# Altium schematic sheet J1708 LIN H-Bridge CAN1 I2C Pots Rev 5.SchDoc("J1708 LIN H-Bridge CAN1 I2C Pots Rev 5")
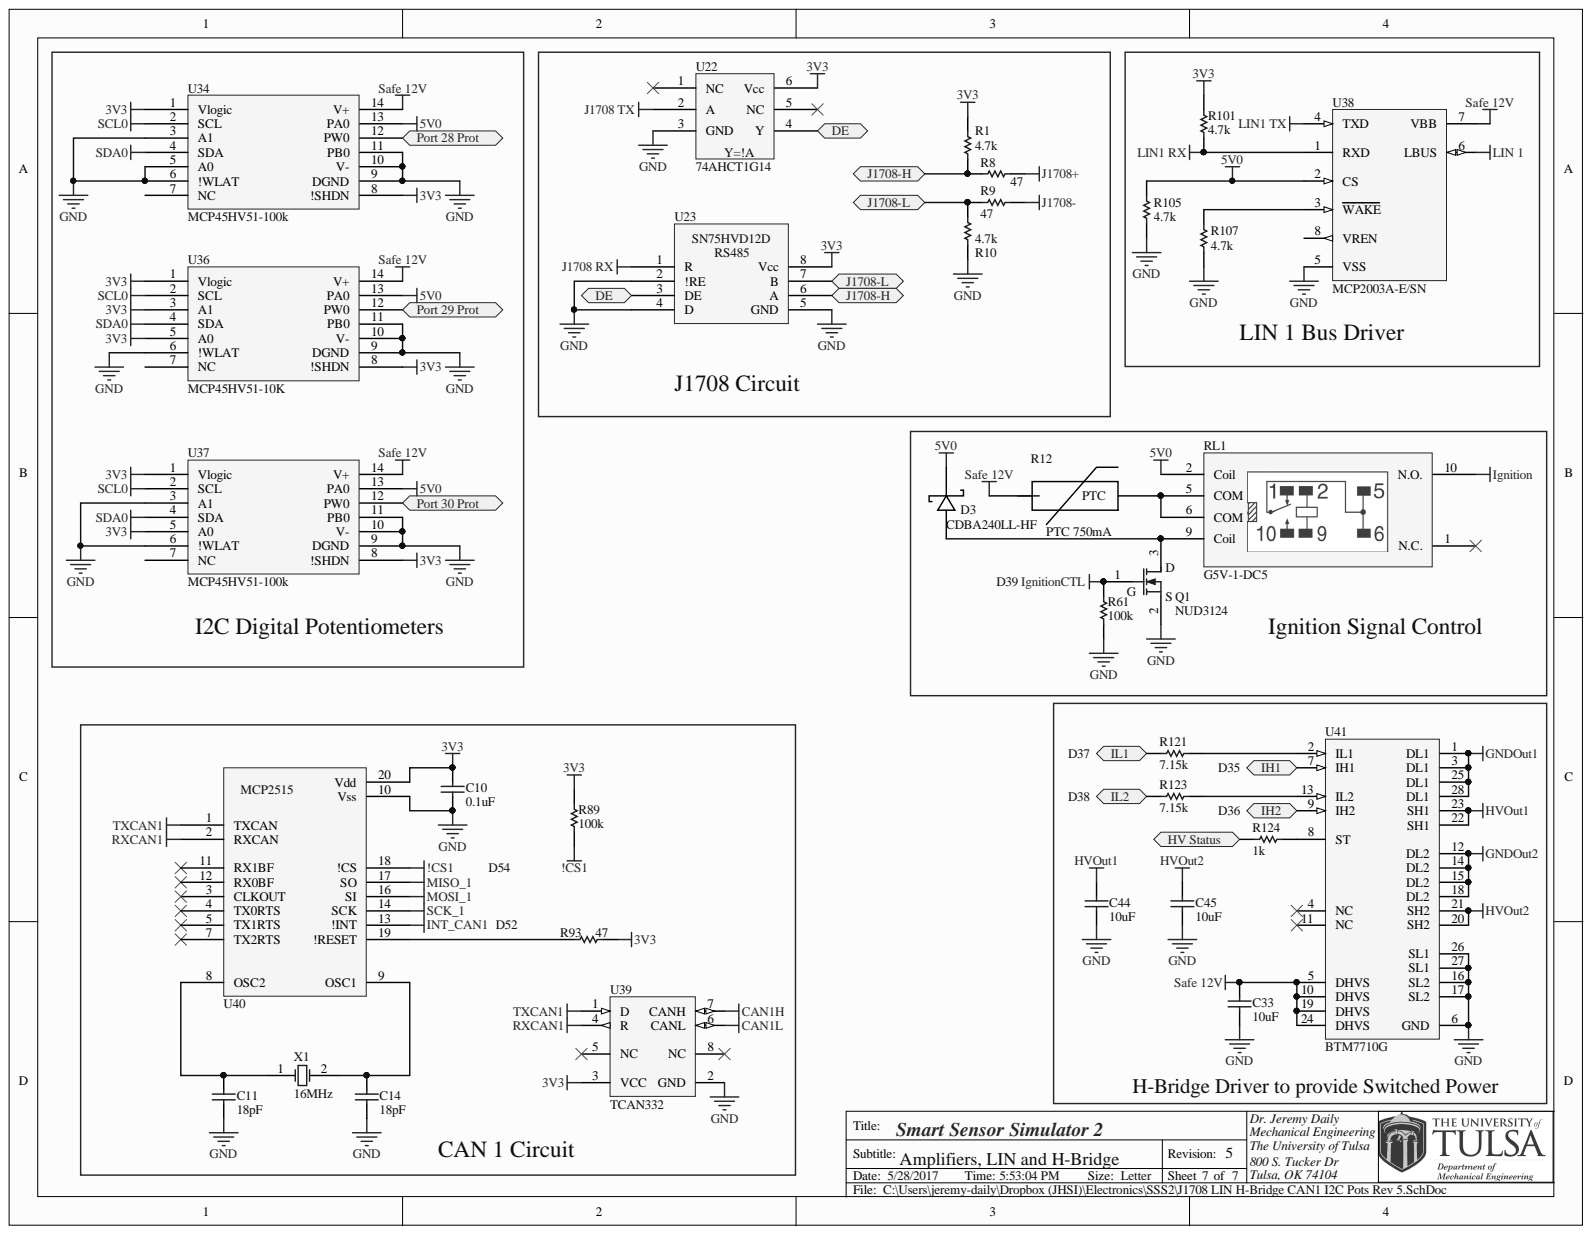
[diagram p0: J1708 LIN H-Bridge CAN1 I2C Pots Rev 5.SchDoc]

## Parts
C10  0.1uF  [0603]
C11  18pF  [0603]
C14  18pF  [0603]
C33  10uF  [0603]
C44  10uF  [0603]
C45  10uF  [0603]
D3  CDBA240LL-HF  [SMA with A and K]
Q1  NUD3124  [TI-DBZ3_N]
R1  4.7k  [0603]
R8  47  [0603]
R9  47  [0603]
R10  4.7k  [0603]
R12  PTC 750mA  [1206]
R61  100k  [0603]
R89  100k  [0603]
R93  47  [0603]
R101  4.7k  [0603]
R105  4.7k  [0603]
R107  4.7k  [0603]
R121  7.15k  [0603]
R123  7.15k  [0603]
R124  1k  [0603]
RL1  G5V-1-DC5  [OMRON G5V Relay]
U22  74AHCT1G14  [SOT-353]
U23  SN75HVD12D (3.3v RS485)  [SOIC-8]  (value not drawn)
U34  MCP45HV51-100k  [TSSOP-ST14_M]
U36  MCP45HV51-10K  [TSSOP-ST14_M]
U37  MCP45HV51-100k  [TSSOP-ST14_M]
U38  MCP2003A-E/SN  [SOIC-SN8_N]
U39  TCAN332  [SN65HVD232]
U40  MCP2515  [TI-TSSOP20]
U41  BTM7710G  [INF-PG-DSO-28-22_M]
X1  16MHz  [XTAL 2 pad]

## Ports
DE, HV STATUS, IH1, IH2, IL1, IL2, J1708-H, J1708-L, PORT 28 PROT, PORT 29 PROT, PORT 30 PROT

## Nets
3V3: C10.2 R1.1 R89.2 R93.2 R101.2 U22.6(Vcc) U23.8(Vcc) U34.1(Vlogic) U34.8(!SHDN) U36.1(Vlogic) U36.3(A1) U36.5(A0) U36.8(!SHDN) U37.1(Vlogic) U37.5(A0) U37.8(!SHDN) U39.3(VCC) U40.20(Vdd)
5V0: D3.2 R105.1 RL1.2(Coil) U34.13(PA0) U36.13(PA0) U37.13(PA0) U38.2(CS)
!CS1: R89.1 U40.18(!CS)
CAN1H: U39.7(CANH)
CAN1L: U39.6(CANL)
GND: C10.1 C11.1 C14.1 C33.2 C44.2 C45.2 Q1.2 R10.2 R61.1 R105.2 R107.2 U22.3(GND) U23.2(!RE) U23.4(D) U23.5(GND) U34.3(A1) U34.5(A0) U34.6(!WLAT) U34.9(DGND) U34.10(V-) U34.11(PB0) U36.6(!WLAT) U36.9(DGND) U36.10(V-) U36.11(PB0) U37.3(A1) U37.6(!WLAT) U37.9(DGND) U37.10(V-) U37.11(PB0) U38.5(VSS) U39.2(GND) U40.10(Vss) U41.6(GND) U41.16(SL2) U41.17(SL2) U41.26(SL1) U41.27(SL1)
GNDOUT1: U41.1(DL1) U41.3(DL1) U41.25(DL1) U41.28(DL1)
GNDOUT2: U41.12(DL2) U41.14(DL2) U41.15(DL2) U41.18(DL2)
HVOUT1: C44.1 U41.22(SH1) U41.23(SH1)
HVOUT2: C45.1 U41.20(SH2) U41.21(SH2)
IGNITION: RL1.10(N.O.)
IGNITIONCTL: Q1.1 R61.2
INT_CAN1: U40.13(!INT)
J1708 RX: U23.1(R)
J1708 TX: U22.2(A)
J1708+: R8.2
J1708-: R9.2
LIN1 RX: R101.1 U38.1(RXD)
LIN1 TX: U38.4(TXD)
LIN 1: U38.6(LBUS)
MISO_1: U40.17(SO)
MOSI_1: U40.16(SI)
RXCAN1: U39.4(R) U40.2(RXCAN)
SAFE 12V: C33.1 R12.1 U34.14(V+) U36.14(V+) U37.14(V+) U38.7(VBB) U41.5(DHVS) U41.10(DHVS) U41.19(DHVS) U41.24(DHVS)
SCK_1: U40.14(SCK)
SCL0: U34.2(SCL) U36.2(SCL) U37.2(SCL)
SDA0: U34.4(SDA) U36.4(SDA) U37.4(SDA)
TXCAN1: U39.1(D) U40.1(TXCAN)
DE: U22.4(Y) U23.3(DE) ; port DE
IH1: U41.7(IH1) ; port IH1
IH2: U41.9(IH2) ; port IH2
IL1: R121.1 ; port IL1
IL2: R123.1 ; port IL2
J1708-H: R1.2 R8.1 U23.6(A) ; port J1708-H
J1708-L: R9.1 R10.1 U23.7(B) ; port J1708-L
NetC11_2: C11.2 U40.8(OSC2) X1.1
NetC14_2: C14.2 U40.9(OSC1) X1.2
NetD3_1: D3.1 Q1.3 RL1.9(Coil)
NetR12_2: R12.2 RL1.5(COM) RL1.6(COM)
NetR93_1: R93.1 U40.19(!RESET)
NetR107_1: R107.1 U38.3(WAKE)
NetR121_2: R121.2 U41.2(IL1)
NetR123_2: R123.2 U41.13(IL2)
NetR124_1: R124.1 ; port HV STATUS
NetR124_2: R124.2 U41.8(ST)
NetU34_12: U34.12(PW0) ; port PORT 28 PROT
NetU36_12: U36.12(PW0) ; port PORT 29 PROT
NetU37_12: U37.12(PW0) ; port PORT 30 PROT
N00311:

Not connected: RL1 1(N.C.); U22 1(NC) 5(NC); U34 7(NC); U36 7(NC); U37 7(NC); U38 8(VREN); U39 5(NC) 8(NC); U40 3(CLKOUT) 4(TX0RTS) 5(TX1RTS) 7(TX2RTS) 11(RX1BF) 12(RX0BF); U41 4(NC) 11(NC)

## Library data of the parts
C10 = Cap: CAP CER 0.1UF 50V 10% X7R 0603; Manufacturer: Murata Electronics North America; PartNumber: GRM188R71H104KA93D; Component Kind: Standard; Library Name: SSS Schematic Library.SchLib; Signal Integrity: Cap; Simulation: CAP; Supplier 1: Digi-Key; Supplier Part Number 1: 490-1519-1-ND
C11 C14 = Cap: CAP CER 18PF 50V 5% NP0 0603; Manufacturer: Kemet; PartNumber: C0603C180J5GACTU; Component Kind: Standard; Library Name: SSS Schematic Library.SchLib; Signal Integrity: Cap; Simulation: CAP; Supplier 1: Digi-Key; Supplier Part Number 1: 399-1052-1-ND
C33 C44 C45 = Cap: 10µF ±20% 25V Ceramic Capacitor X5R 0603 (1608 Metric); Manufacturer: Murata Electronics North America; PartNumber: GRT188R61E106ME13D; Component Kind: Standard; Library Name: SSS Integrated Library.IntLib; Signal Integrity: Cap; Simulation: CAP; Supplier 1: Digi-Key; Supplier Part Number 1: 490-12323-1-ND
D3 = Schottky Diode: Diode Schottky 40V 2A Surface Mount DO-214AC (SMA); Manufacturer: Comchip Technology; Component Kind: Standard; Library Name: SSS Integrated Library.IntLib; PartNumber: CDBA240LL-HF; Supplier 1: Digi-Key; Supplier Part Number 1: 641-1698-1-ND
Q1 = IRLML6344TRPbF: IC INDCT LOAD DRVR AUTO SOT23; Manufacturer: ON Semiconductor; PartNumber: NUD3124LT1G; Component Kind: Standard; Library Name: SSS Schematic Library.SchLib; Supplier 1: Digi-Key; Supplier Part Number 1: NUD3124LT1GOSCT-ND
R1 R10 R101 R105 R107 = Chip Resistor: RES SMD 4.7K OHM 1% 1/10W 0603; Manufacturer: Panasonic Electronic Components; PartNumber: ERJ-3EKF4701V; Component Kind: Standard; Library Name: SSS Integrated Library.IntLib; Supplier 1: Digi-Key; Supplier Part Number 1: P4.70KHCT-ND
R8 R9 R93 = Chip Resistor: RES SMD 47 OHM 1% 1/10W 0603; Manufacturer: Panasonic Electronic Components; PartNumber: ERJ-3EKF47R0V; Component Kind: Standard; Library Name: SSS Integrated Library.IntLib; Supplier 1: Digi-Key; Supplier Part Number 1: P47.0HCT-ND
R12 = PTC: PTC RESTTBLE 0.75A 16V CHIP 1206; Manufacturer: Bel Fuse Inc; PartNumber: 0ZCJ0075AF2E; Component Kind: Standard; Library Name: SSS Schematic Library.SchLib; Supplier 1: Digi-Key; Supplier Part Number 1: 507-1805-1-ND
R61 R89 = Chip Resistor: RES SMD 100K OHM 1% 1/10W 0603; Manufacturer: Panasonic Electronic Components; PartNumber: ERJ-3EKF1003V; Component Kind: Standard; Library Name: SSS Integrated Library.IntLib; Supplier 1: Digi-Key; Supplier Part Number 1: P100KHCT-ND
R121 R123 = Chip Resistor: RES SMD 7.15K OHM 1% 1/10W 0603; Manufacturer: Panasonic Electronic Components; PartNumber: ERJ-3EKF7151V; Component Kind: Standard; Library Name: SSS Integrated Library.IntLib; Supplier 1: Digi-Key; Supplier Part Number 1: P7.15KHCT-ND
R124 = Chip Resistor: RES SMD 1K OHM 1% 1/10W 0603; Manufacturer: Panasonic Electronic Components; PartNumber: ERJ-3EKF1001V; Component Kind: Standard; Library Name: SSS Schematic Library.SchLib; Supplier 1: Digi-Key; Supplier Part Number 1: P1.00KHCT-ND
RL1 = Relay-G5V: OMRON RELAY GENERAL PURPOSE SPDT 1A 5V; Manufacturer: Omron Electronics Inc-EMC Div; Component Kind: Standard; Library Name: SSS Schematic Library.SchLib; PartNumber: G5V-1-DC5; Supplier 1: Digi-Key; Supplier Part Number 1: Z773-ND
U22 = 74AHCT1G14 Inverter: IC SCHMIT-TRIGG INV GATE SOT353; Manufacturer: Diodes Incorporated; PartNumber: 74AHCT1G14SE-7; Component Kind: Standard; Library Name: SSS Schematic Library.SchLib; Supplier 1: Digi-Key; Supplier Part Number 1: 74AHCT1G14SE-7DICT-ND
U23 = SN75HVD12D (3.3v RS485): IC RS485 TXRX 3/5V 8-SOIC; Manufacturer: Texas Instruments; PartNumber: SN75HVD08DR; Component Kind: Standard; Library Name: SSS Schematic Library.SchLib; Supplier 1: Digi-Key; Supplier Part Number 1: 296-37893-1-ND
U34 U37 = MCP45HVX1 Digital Pot: Digital Potentiometer 100k Ohm 1 Circuit 256 Taps I²C Interface 14-TSSOP; Manufacturer: Microchip Technology; PartNumber: MCP45HV51-104E/ST; Component Kind: Standard; Library Name: SSS Integrated Library.IntLib; Supplier 1: Digi-Key; Supplier Part Number 1: MCP45HV51-104E/ST-ND
U36 = MCP45HVX1 Digital Pot: Digital Potentiometer 10k Ohm 1 Circuit 256 Taps I²C Interface 14-TSSOP; Manufacturer: Microchip Technology; PartNumber: MCP45HV51-103E/ST; Component Kind: Standard; Library Name: SSS Integrated Library.IntLib; Supplier 1: Digi-Key; Supplier Part Number 1: MCP45HV51-103E/ST-ND
U38 = MCP2003AT-E/SN: LIN J2602 Transceiver, 8-Pin SOIC, Extended Temperature, Tape and Reel; Manufacturer: Microchip; Component Kind: Standard; Library Name: Microchip Interface.IntLib; PartNumber: MCP2003A-E/SN; Supplier 1: Digi-Key; Supplier Part Number 1: MCP2003A-E/SN-ND
U39 = SN65HVD232D: 3.3 V CAN Transceiver, 17 mA, -40 to 85 degC, 8-pin SOIC (D), Green (RoHS & no Sb/Br); Manufacturer: Texas Instruments; Component Kind: Standard; Library Name: Texas Instruments Interface CAN.IntLib; PartNumber: SN65HVD232D; Supplier 1: Digi-Key; Supplier Part Number 1: 296-12282-5-ND
U40 = MCP2515 (TSSOP): IC CAN CONTROLLER W/SPI 20TSSOP; Manufacturer: Microchip; PartNumber: MCP2515T-I/ST; Component Kind: Standard; Supplier 1: Digi-Key; Supplier Part Number 1: MCP2515T-I/STCT-ND; Library Name: Communications.SchLib
U41 = BTM7710G: TrilithIC, 4.5 to 42 V, -40 to 150 degC, PG-DSO-28-22, Reel, Green; Manufacturer: Infineon; Component Kind: Standard; Library Name: Infineon_Power_Motor_Driver.IntLib; PartNumber: BTM7710G; Supplier 1: Digi-Key; Supplier Part Number 1: BTM7710GXUMA1CT-ND
X1 = Crystal Oscillator: CRYSTAL 16MHZ 18PF SMD; Manufacturer: TXC CORPORATION; PartNumber: 7A-16.000MAAJ-T; Component Kind: Standard; Library Name: SSS Schematic Library.SchLib; Supplier 1: Digi-Key; Supplier Part Number 1: 887-1086-1-ND
